# Altium schematic sheet jlin_transceiver_prototype.SchDoc
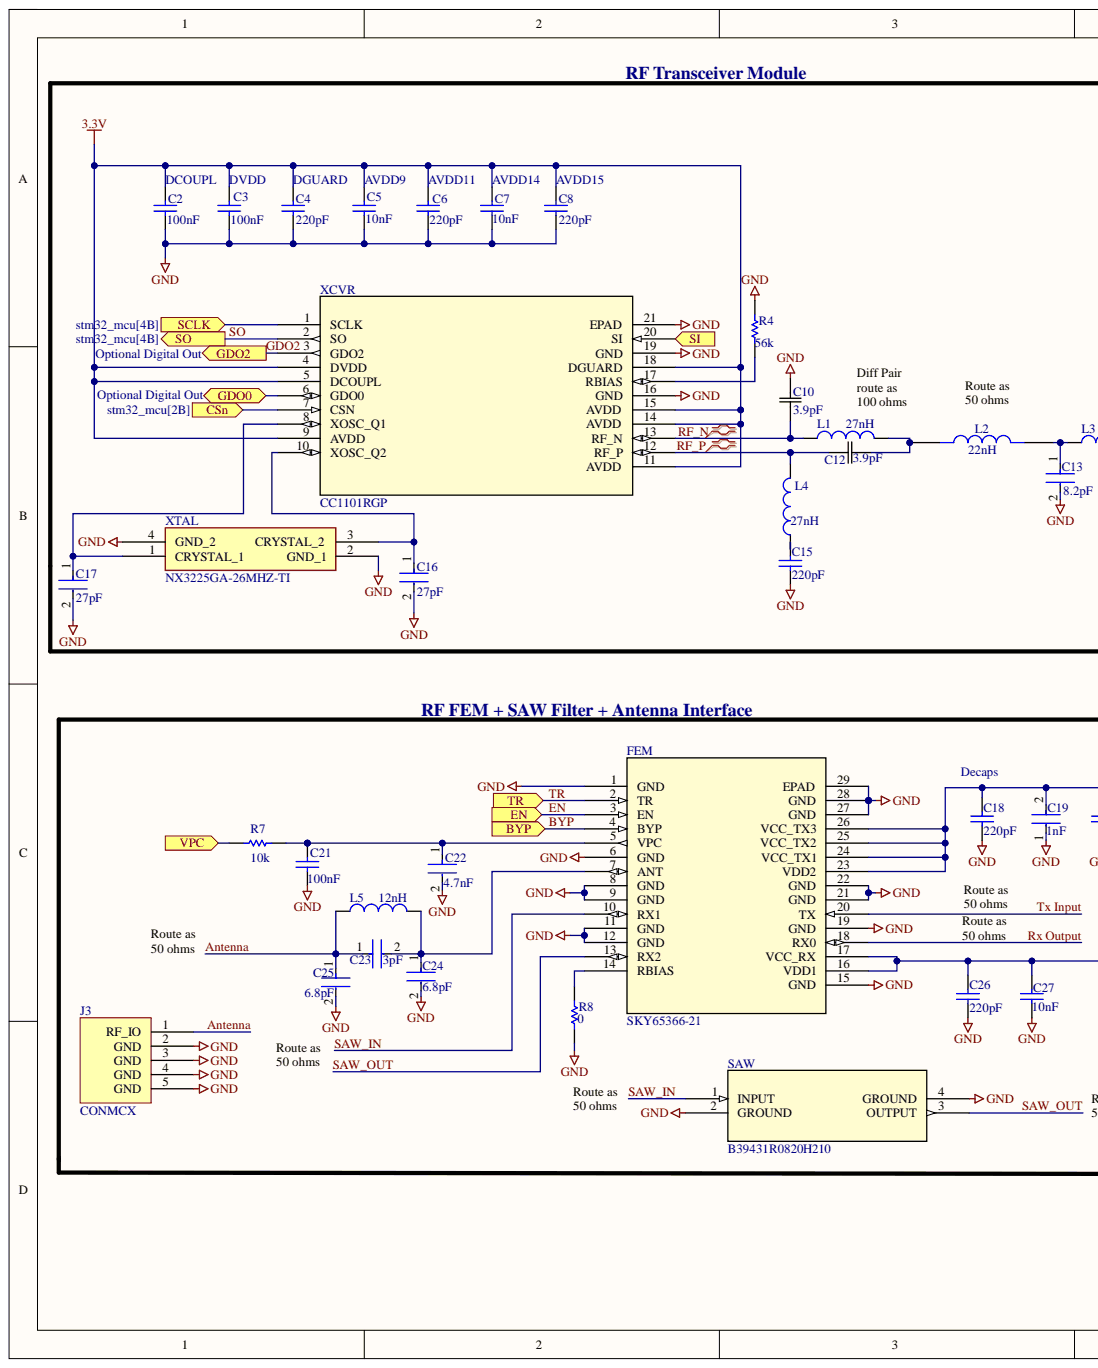
[diagram p0: jlin_transceiver_prototype.SchDoc, left]
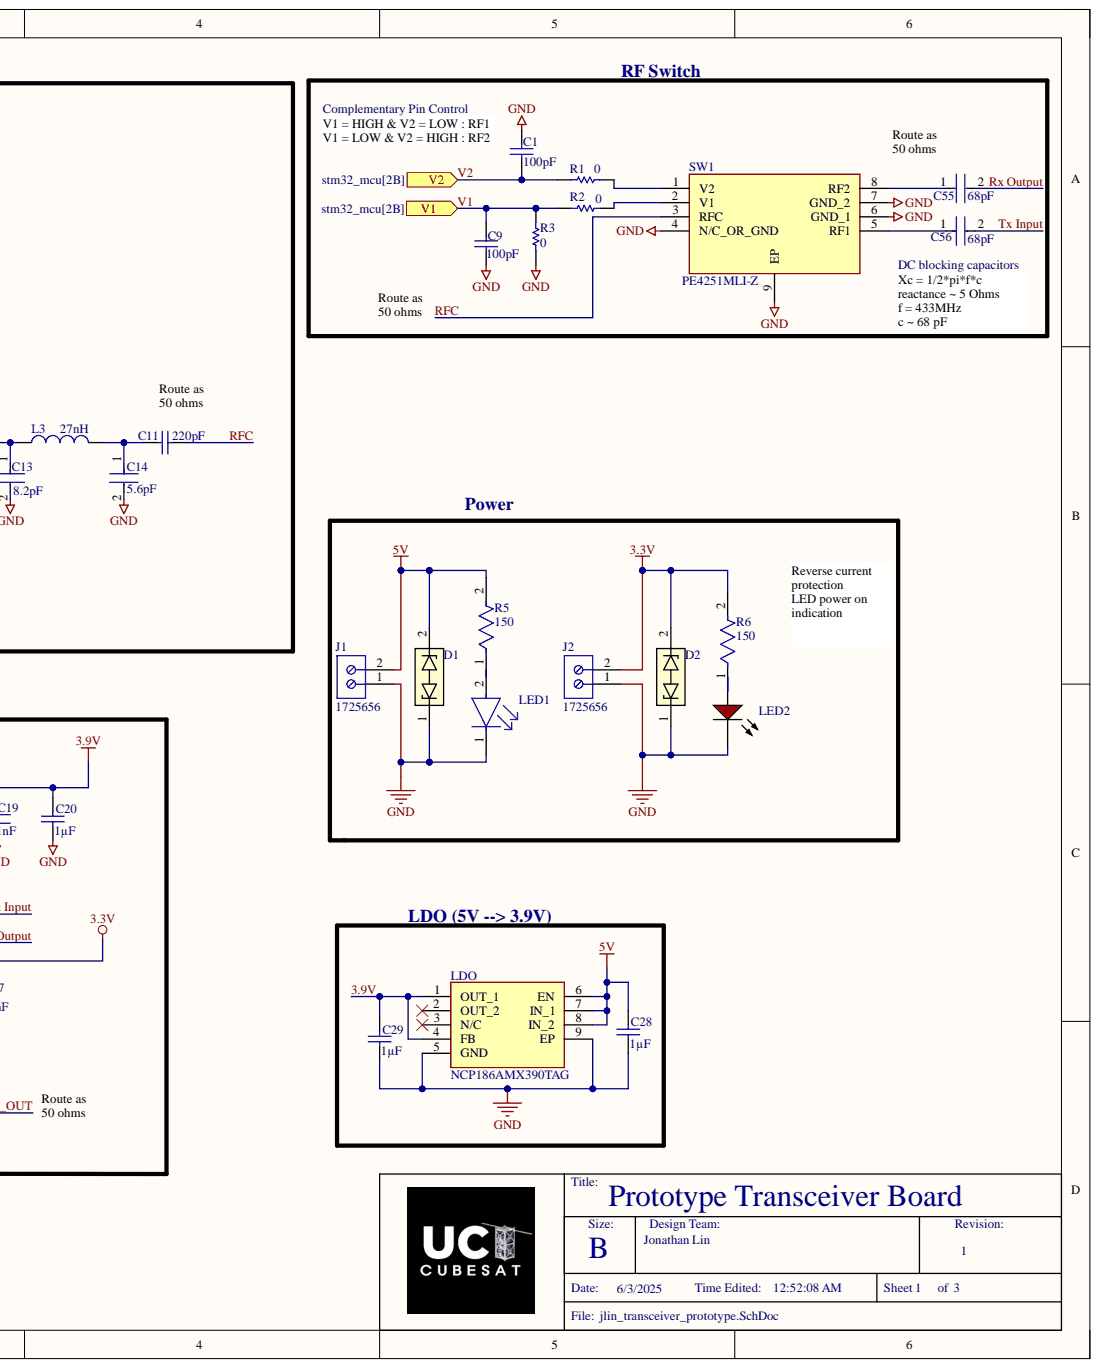
[diagram p1: jlin_transceiver_prototype.SchDoc, right]

## Parts
C1  value 100pF  [CAPC2013X70X45LL10T25]  (hidden comment: GRM2165C1H101JA01D)
C2  value 100nF  [CAPC2013X135X45ML10T25]  (hidden comment: GRM21BR72A104KAC4L)
C3  value 100nF  [CAPC2013X135X45ML10T25]  (hidden comment: GRM21BR72A104KAC4L)
C4  value 220pF  [CAPC2013X70X45LL10T25]  (hidden comment: GRM2165C1H221JA01D)
C5  value 10nF  [CAPC2013X135X45ML10T25]  (hidden comment: GRM21BR72A103KA01K)
C6  value 220pF  [CAPC2013X70X45LL10T25]  (hidden comment: GRM2165C1H221JA01D)
C7  value 10nF  [CAPC2013X135X45ML10T25]  (hidden comment: GRM21BR72A103KA01K)
C8  value 220pF  [CAPC2013X70X45LL10T25]  (hidden comment: GRM2165C1H221JA01D)
C9  value 100pF  [CAPC2013X70X45LL10T25]  (hidden comment: GRM2165C1H101JA01D)
C10  value 3.9pF  [CAP_CC0805_YAG]  (hidden comment: CC0805CRNPO9BN3R9)
C11  value 220pF  [CAPC2013X70X45LL10T25]  (hidden comment: GRM2165C1H221JA01D)
C12  value 3.9pF  [CAP_CC0805_YAG]  (hidden comment: CC0805CRNPO9BN3R9)
C13  value 8.2pF  [FP-0805-L_2_01_0_2-W_1_25-MFG]  (hidden comment: KGM21BCG2A8R2DT)
C14  value 5.6pF  [FP-C0805C-DN-IPC_A]  (hidden comment: C0805C569C5GACTU)
C15  value 220pF  [CAPC2013X70X45LL10T25]  (hidden comment: GRM2165C1H221JA01D)
C16  value 27pF  [FP-GRM21A-0_2-IPC_B]  (hidden comment: GRM21A5C2E270JW01D)
C17  value 27pF  [FP-GRM21A-0_2-IPC_B]  (hidden comment: GRM21A5C2E270JW01D)
C18  value 220pF  [CAPC2013X70X45LL10T25]  (hidden comment: GRM2165C1H221JA01D)
C19  value 1nF  [FP-GRM216-0_1-IPC_B]  (hidden comment: GRM2165C2A102JA01D)
C20  value 1µF  [CAPC2013X135X45LL10T25]  (hidden comment: GRM21BR71C105KA01L)
C21  value 100nF  [CAPC2013X135X45ML10T25]  (hidden comment: GRM21BR72A104KAC4L)
C22  value 4.7nF  [FP-GRM219-0_1-MFG]  (hidden comment: GRM2195C1H472JA01D)
C23  value 3pF  [FP-C0805C-DN-IPC_A]  (hidden comment: C0805C309C5GACTU)
C24  value 6.8pF  [FP-GQM219-0_15-MFG]  (hidden comment: GQM2195C2E6R8BB12D)
C25  value 6.8pF  [FP-GQM219-0_15-MFG]  (hidden comment: GQM2195C2E6R8BB12D)
C26  value 220pF  [CAPC2013X70X45LL10T25]  (hidden comment: GRM2165C1H221JA01D)
C27  value 10nF  [CAPC2013X135X45ML10T25]  (hidden comment: GRM21BR72A103KA01K)
C28  value 1µF  [CAPC2013X135X45LL10T25]  (hidden comment: GRM21BR71C105KA01L)
C29  value 1µF  [CAPC2013X135X45LL10T25]  (hidden comment: GRM21BR71C105KA01L)
C55  value 68pF  [FP-GRM21A-0_2-IPC_B]  (hidden comment: GRM21A5C2E680JW01D)
C56  value 68pF  [FP-GRM21A-0_2-IPC_B]  (hidden comment: GRM21A5C2E680JW01D)
D1  PESD3V3Z1BCSFYL  [SOD962-2_DSN0603-2_NEX]  (value not drawn)
D2  PESD3V3Z1BCSFYL  [SOD962-2_DSN0603-2_NEX]  (value not drawn)
FEM  SKY65366-21  [SKY65366-21-Footprint-1]
J1  1725656  [PHOE-1725656-2]
J2  1725656  [PHOE-1725656-2]
J3  CONMCX  [CONMCX002-Footprint-1]
L1  value 27nH  [WE-MK_0805_L2W1.25H0.85]  (hidden comment: 7447880127)
L2  value 22nH  [INDC2012X105N]  (hidden comment: AIMC-0805-22NJ-T)
L3  value 27nH  [WE-MK_0805_L2W1.25H0.85]  (hidden comment: 7447880127)
L4  value 27nH  [WE-MK_0805_L2W1.25H0.85]  (hidden comment: 7447880127)
L5  value 12nH  [AIMC-0805_ABR]  (hidden comment: AIMC-0805-12NJ-T)
LDO  NCP186AMX390TAG  [NCP186AMX330TAG]
LED1  LTST-C170KFKT  [FP-LTST-C170KFKT-MFG]  (value not drawn)
LED2  LTST-C190KRKT  [LTST-C191KRKT_V]  (value not drawn)
R1  value 0  [RESC2013X60X35ML10T20]  (hidden comment: Resistor 0R +/- 5% 0805 125 mW)
R2  value 0  [RESC2013X60X35ML10T20]  (hidden comment: Resistor 0R +/- 5% 0805 125 mW)
R3  value 0  [RESC2013X60X35ML10T20]  (hidden comment: Resistor 0R +/- 5% 0805 125 mW)
R4  value 56k  [RESC2013X70X40NL20T20]  (hidden comment: ERJ-6GEYJ563V)
R5  value 150  [FP-CRCW1210-e3-IPC_C]  (hidden comment: CRCW1210150RJNEA)
R6  value 150  [FP-CRCW1210-e3-IPC_C]  (hidden comment: CRCW1210150RJNEA)
R7  value 10k  [RESC2013X60X35LL10T20]  (hidden comment: RC0805FR-0710KL)
R8  value 0  [RESC2013X60X35ML10T20]  (hidden comment: Resistor 0R +/- 5% 0805 125 mW)
SAW  B39431R0820H210  [B39431R0820H210_QUA]
SW1  PE4251MLI-Z  [SOP65P490X106-9N]
XCVR  CC1101RGP  [CC1101RGP-Footprint-1]
XTAL  NX3225GA-26MHZ-TI  [NX3225GA_NDK]

## Ports
BYP, CSn, EN, GDO0, GDO2, SCLK, SI, SO, TR, V1, V2, VPC

## Nets
3.3V: C2.2 C3.2 C4.2 C5.2 C6.2 C7.2 C8.2 C26.2 C27.2 D2.2 FEM.16(VDD1) FEM.17(VCC_RX) J2.2 R6.2 XCVR.4(DVDD) XCVR.5(DCOUPL) XCVR.9(AVDD) XCVR.11(AVDD) XCVR.14(AVDD) XCVR.15(AVDD) XCVR.18(DGUARD)
5V: C28.2 D1.2 J1.2 LDO.6(EN) LDO.7(IN_1) LDO.8(IN_2) R5.2
GND: C1.2 C2.1 C3.1 C4.1 C5.1 C6.1 C7.1 C8.1 C9.1 C10.2 C13.2 C14.2 C15.1 C16.2 C17.2 C18.1 C19.1 C20.1 C21.1 C22.2 C24.2 C25.2 C26.1 C27.1 C28.1 C29.1 D1.1 D2.1 FEM.1(GND) FEM.6(GND) FEM.8(GND) FEM.9(GND) FEM.11(GND) FEM.12(GND) FEM.15(GND) FEM.19(GND) FEM.21(GND) FEM.22(GND) FEM.27(GND) FEM.28(GND) FEM.29(EPAD) J1.1 J2.1 J3.2(GND) J3.3(GND) J3.4(GND) J3.5(GND) LDO.5(GND) LDO.9(EP) LED1.1 LED2.2 R3.1 R4.2 R8.1 SAW.2(GROUND) SAW.4(GROUND) SW1.4(N/C_OR_GND) SW1.6(GND_1) SW1.7(GND_2) SW1.9(EP) XCVR.16(GND) XCVR.19(GND) XCVR.21(EPAD) XTAL.2(GND_1) XTAL.4(GND_2)
3.9V: C18.2 C19.2 C20.2 C29.2 FEM.23(VDD2) FEM.24(VCC_TX1) FEM.25(VCC_TX2) FEM.26(VCC_TX3) LDO.1(OUT_1) LDO.4(FB)
Antenna: C23.1 C25.1 J3.1(RF_IO) L5.1
BYP: FEM.4(BYP) ; port BYP
CSn: XCVR.7(CSN) ; port CSn
EN: FEM.3(EN) ; port EN
GDO0: XCVR.6(GDO0) ; port GDO0
GDO2: XCVR.3(GDO2) ; port GDO2
RF_N: C10.1 L1.1 XCVR.13(RF_N)
RF_P: C12.1 L4.2 XCVR.12(RF_P)
RFC: C11.2 SW1.3(RFC)
Rx Output: C55.2 FEM.18(RX0)
SAW_IN: FEM.10(RX1) SAW.1(INPUT)
SAW_OUT: FEM.13(RX2) SAW.3(OUTPUT)
SCLK: XCVR.1(SCLK) ; port SCLK
SI: XCVR.20(SI) ; port SI
SO: XCVR.2(SO) ; port SO
TR: FEM.2(TR) ; port TR
Tx Input: C56.2 FEM.20(TX)
V1: C9.2 R2.1 R3.2 ; port V1
V2: C1.1 R1.1 ; port V2
VPC: R7.1 ; port VPC
NetC11_1: C11.1 C14.1 L3.2
NetC12_2: C12.2 L1.2 L2.1
NetC13_1: C13.1 L2.2 L3.1
NetC15_2: C15.2 L4.1
NetC16_1: C16.1 XCVR.10(XOSC_Q2) XTAL.3(CRYSTAL_2)
NetC17_1: C17.1 XCVR.8(XOSC_Q1) XTAL.1(CRYSTAL_1)
NetC21_2: C21.2 C22.1 FEM.5(VPC) R7.2
NetC23_2: C23.2 C24.1 FEM.7(ANT) L5.2
NetC55_1: C55.1 SW1.8(RF2)
NetC56_1: C56.1 SW1.5(RF1)
NetFEM_14: FEM.14(RBIAS) R8.2
NetLED1_2: LED1.2 R5.1
NetLED2_1: LED2.1 R6.1
NetR1_2: R1.2 SW1.1(V2)
NetR2_2: R2.2 SW1.2(V1)
NetR4_1: R4.1 XCVR.17(RBIAS)

Not connected: LDO 2(OUT_2) 3(N/C)

## Library data of the parts
C1 C9 = CMP-001-00139-1: Ceramic Capacitor, Multilayer, Ceramic, 50V, 5% +Tol, 5% -Tol, C0G, -/+30ppm/Cel TC, 0.0001uF, Surface Mount, 0805; Multilayer: Yes; Capacitor Type: Ceramic; Case/Package: 0805; Component Kind: Standard; Dielectric Material: Ceramic; Height: 0.6mm; JESD-609 Code: e3; Length: 2mm; Max Operating Temperature: 125°C; Min Operating Temperature: -55°C; Mounting Technology: Surface Mount; Package Shape: Rectangular; Package Style: SMTMeter; Packaging: Tape and Reel; Pins: 2; Rated DC Voltage (URdc): 50V; Reach SVHC Compliant: Yes; RoHS Compliant: Yes; Temperature Characteristics Code: C0G; Terminal Finish: Nickel; Termination: Wraparound; Tolerance  (fill in as +-): 5%; Tolerance (fill in as +-): 5%; Width: 1.25mm; Library Name: University of California Irvine
C2 C3 C21 = CMP-001-00124-1: Ceramic Capacitor, Multilayer, Ceramic, 100V, 10% +Tol, 10% -Tol, X7R, 15% TC, 0.1uF, Surface Mount, 0805; Multilayer: Yes; Capacitor Type: Ceramic; Case/Package: 0805; Component Kind: Standard; Dielectric Material: Ceramic; Height: 1.25mm; JESD-609 Code: e3; Length: 2mm; Max Operating Temperature: 125°C; Min Operating Temperature: -55°C; Mounting Technology: Surface Mount; Package Shape: Rectangular; Package Style: SMTMeter; Packaging: Tape and Reel; Pins: 2; Rated DC Voltage (URdc): 100V; Reach SVHC Compliant: Yes; RoHS Compliant: Yes; Temperature Characteristics Code: X7R; Terminal Finish: Nickel; Termination: Wraparound; Tolerance  (fill in as +-): 10%; Tolerance (fill in as +-): 10%; Width: 1.25mm; Library Name: University of California Irvine
C4 C6 C8 C11 C15 C18 C26 = CMP-001-00130-1: Ceramic Capacitor, Multilayer, Ceramic, 50V, 5% +Tol, 5% -Tol, C0G, -/+30ppm/Cel TC, 0.00022uF, Surface Mount, 0805; Multilayer: Yes; Capacitor Type: Ceramic; Case/Package: 0805; Component Kind: Standard; Dielectric Material: Ceramic; Height: 0.6mm; JESD-609 Code: e3; Length: 2mm; Max Operating Temperature: 125°C; Min Operating Temperature: -55°C; Mounting Technology: Surface Mount; Package Shape: Rectangular; Package Style: SMTMeter; Packaging: Tape and Reel; Pins: 2; Rated DC Voltage (URdc): 50V; Reach SVHC Compliant: Yes; RoHS Compliant: Yes; Temperature Characteristics Code: C0G; Terminal Finish: Nickel; Termination: Wraparound; Tolerance  (fill in as +-): 5%; Tolerance (fill in as +-): 5%; Width: 1.25mm; Library Name: University of California Irvine
  C4 C6 C8: ComponentLink1Description: New link
C5 C7 C27 = CMP-001-00132-1: Ceramic Capacitor, Multilayer, Ceramic, 100V, 10% +Tol, 10% -Tol, X7R, 15% TC, 0.01uF, Surface Mount, 0805; Multilayer: Yes; Capacitor Type: Ceramic; Case/Package: 0805; Component Kind: Standard; Dielectric Material: Ceramic; Height: 1.25mm; JESD-609 Code: e3; Length: 2mm; Max Operating Temperature: 125°C; Min Operating Temperature: -55°C; Mounting Technology: Surface Mount; Package Shape: Rectangular; Package Style: SMTMeter; Packaging: Tape and Reel; Pins: 2; Rated DC Voltage (URdc): 100V; Reach SVHC Compliant: Yes; RoHS Compliant: Yes; Temperature Characteristics Code: X7R; Terminal Finish: Nickel; Termination: Wraparound; Tolerance  (fill in as +-): 10%; Tolerance (fill in as +-): 10%; Width: 1.25mm; Library Name: University of California Irvine
  C5 C7: ComponentLink1Description: New link
C10 C12 = CMP-001-00101-5: 3.9 pF ±0.25pF 50V Ceramic Capacitor C0G, NP0 0805 (2012 Metric); Mfr_Name: Yageo; Capacitor Type: Ceramic; Case/Package: 0805; Component Kind: Standard; Component Type: Standard; Design Item ID: CC0805CRNPO9BN3R9; Max Operating Temperature: 125°C; Min Operating Temperature: -55°C; Mounting Technology: Surface Mount; Pins: 2; RoHS Compliant: Yes; Source: CC0805CRNPO9BN3R9.SchLib; Library Name: University of California Irvine
C13 = CMP-001-00135-2: Cap Cer 8.2PF 100V C0G/NP0 0805; Capacitor Type: Ceramic; Multilayer: Yes; Temperature Characteristics Code: C0G; Tolerance  (fill in as +-): 6.0976%; Case/Package: 0805; Component Kind: Standard; Dielectric Material: Ceramic; Height: 0.94mm; JESD-609 Code: e3; Length: 2.01mm; Max Operating Temperature: 125°C; Min Operating Temperature: -55°C; Mounting Technology: Surface Mount; Package Shape: Rectangular; Package Style: SMTMeter; Packaging: Tape and Reel; Pins: 2; Rated DC Voltage (URdc): 100V; Reach SVHC Compliant: Yes; RoHS Compliant: Yes; Terminal Finish: Nickel; Termination: Wraparound; Tolerance (fill in as +-): 6.0976%; Width: 1.25mm; Library Name: University of California Irvine
C14 = CMP-001-00134-1: Ceramic Capacitor, Multilayer, Ceramic, 50V, 4.46% +Tol, 4.46% -Tol, C0G, 30ppm/Cel TC, 0.0000056uF, Surface Mount, 0805; Multilayer: Yes; Tolerance  (fill in as +-): 4.4643%; Capacitor Type: Ceramic; Case/Package: 0805; Component Kind: Standard; Dielectric Material: Ceramic; Height: 0.78mm; JESD-609 Code: e3; Length: 2mm; Max Operating Temperature: 125°C; Min Operating Temperature: -55°C; Mounting Technology: Surface Mount; Package Shape: Rectangular; Package Style: SMTMeter; Packaging: Tape and Reel; Pins: 2; Rated DC Voltage (URdc): 50V; Reach SVHC Compliant: Yes; RoHS Compliant: Yes; Temperature Characteristics Code: C0G; Terminal Finish: Nickel; Termination: Wraparound; Tolerance (fill in as +-): 4.4643%; Width: 1.25mm; Library Name: University of California Irvine
C16 C17 = CMP-001-00133-1: Ceramic Capacitor, Multilayer, Ceramic, 250V, 5% +Tol, 5% -Tol, C0G, 30ppm/Cel TC, 0.000027uF, Surface Mount, 0805; Multilayer: Yes; Capacitor Type: Ceramic; Case/Package: 0805; Component Kind: Standard; Dielectric Material: Ceramic; Height: 1mm; JESD-609 Code: e3; Length: 2mm; Max Operating Temperature: 125°C; Min Operating Temperature: -55°C; Mounting Technology: Surface Mount; Package Shape: Rectangular; Package Style: SMTMeter; Packaging: Tape and Reel; Pins: 2; Rated DC Voltage (URdc): 250V; Reach SVHC Compliant: Yes; RoHS Compliant: Yes; Temperature Characteristics Code: C0G; Terminal Finish: Nickel; Termination: Wraparound; Tolerance  (fill in as +-): 5%; Tolerance (fill in as +-): 5%; Width: 1.25mm; Library Name: University of California Irvine
C19 = CMP-001-00136-1: Ceramic Capacitor, Multilayer, Ceramic, 100V, 5% +Tol, 5% -Tol, C0G, 30ppm/Cel TC, 0.001uF, Surface Mount, 0805; Multilayer: Yes; Capacitor Type: Ceramic; Case/Package: 0805; Component Kind: Standard; Dielectric Material: Ceramic; Height: 0.6mm; JESD-609 Code: e3; Length: 2mm; Max Operating Temperature: 125°C; Min Operating Temperature: -55°C; Mounting Technology: Surface Mount; Package Shape: Rectangular; Package Style: SMTMeter; Packaging: Tape and Reel; Pins: 2; Rated DC Voltage (URdc): 100V; Reach SVHC Compliant: Yes; RoHS Compliant: Yes; Temperature Characteristics Code: C0G; Terminal Finish: Nickel; Termination: Wraparound; Tolerance  (fill in as +-): 5%; Tolerance (fill in as +-): 5%; Width: 1.25mm; Library Name: University of California Irvine
C20 C28 C29 = CMP-001-00129-1: Ceramic Capacitor, Multilayer, Ceramic, 16V, 10% +Tol, 10% -Tol, X7R, 15% TC, 1uF, Surface Mount, 0805; Multilayer: Yes; Capacitor Type: Ceramic; Case/Package: 0805; Component Kind: Standard; Dielectric Material: Ceramic; Height: 1.25mm; JESD-609 Code: e3; Length: 2mm; Max Operating Temperature: 125°C; Min Operating Temperature: -55°C; Mounting Technology: Surface Mount; Package Shape: Rectangular; Package Style: SMTMeter; Packaging: Tape and Reel; Pins: 2; Rated DC Voltage (URdc): 16V; Reach SVHC Compliant: Yes; RoHS Compliant: Yes; Temperature Characteristics Code: X7R; Terminal Finish: Nickel; Termination: Wraparound; Tolerance  (fill in as +-): 10%; Tolerance (fill in as +-): 10%; Width: 1.25mm; Library Name: University of California Irvine
C22 = CMP-001-00138-1: Ceramic Capacitor, Multilayer, Ceramic, 50V, 5% +Tol, 5% -Tol, C0G, 30ppm/Cel TC, 0.0047uF, Surface Mount, 0805; Multilayer: Yes; Capacitor Type: Ceramic; Case/Package: 0805; Component Kind: Standard; Dielectric Material: Ceramic; Height: 0.85mm; JESD-609 Code: e3; Length: 2mm; Max Operating Temperature: 125°C; Min Operating Temperature: -55°C; Mounting Technology: Surface Mount; Package Shape: Rectangular; Package Style: SMTMeter; Packaging: Tape and Reel; Pins: 2; Rated DC Voltage (URdc): 50V; Reach SVHC Compliant: Yes; RoHS Compliant: Yes; Temperature Characteristics Code: C0G; Terminal Finish: Nickel; Termination: Wraparound; Tolerance  (fill in as +-): 5%; Tolerance (fill in as +-): 5%; Width: 1.25mm; Library Name: University of California Irvine
C23 = CMP-001-00142-1: Ceramic Capacitor, Multilayer, Ceramic, 50V, 8.33% +Tol, 8.33% -Tol, C0G, 30ppm/Cel TC, 0.000003uF, Surface Mount, 0805; Multilayer: Yes; Tolerance  (fill in as +-): 8.3333%; Capacitor Type: Ceramic; Case/Package: 0805; Component Kind: Standard; Dielectric Material: Ceramic; Height: 0.78mm; JESD-609 Code: e3; Length: 2mm; Max Operating Temperature: 125°C; Min Operating Temperature: -55°C; Mounting Technology: Surface Mount; Package Shape: Rectangular; Package Style: SMTMeter; Packaging: Tape and Reel; Pins: 2; Rated DC Voltage (URdc): 50V; Reach SVHC Compliant: Yes; RoHS Compliant: Yes; Temperature Characteristics Code: C0G; Terminal Finish: Nickel; Termination: Wraparound; Tolerance (fill in as +-): 8.3333%; Width: 1.25mm; Library Name: University of California Irvine
C24 C25 = CMP-001-00143-1: Ceramic Capacitor, Multilayer, Ceramic, 250V, 1.47% +Tol, 1.47% -Tol, C0G, -/+30ppm/Cel TC, 0.0000068uF, Surface Mount, 0805; Multilayer: Yes; Capacitor Type: Ceramic; Case/Package: 0805; Component Kind: Standard; Dielectric Material: Ceramic; JESD-609 Code: e3; Max Operating Temperature: 125°C; Min Operating Temperature: -55°C; Mounting Technology: Surface Mount; Package Shape: Rectangular; Packaging: Tape and Reel; Pins: 2; Rated DC Voltage (URdc): 250V; Reach SVHC Compliant: Yes; RoHS Compliant: Yes; Temperature Characteristics Code: C0G; Terminal Finish: Nickel; Termination: Wraparound; Tolerance  (fill in as +-): 1.47%; Tolerance (fill in as +-): 1.47%; Library Name: University of California Irvine
C55 C56 = CMP-001-00140-1: Ceramic Capacitor, Multilayer, Ceramic, 250V, 5% +Tol, 5% -Tol, C0G, 30ppm/Cel TC, 0.000068uF, Surface Mount, 0805; Multilayer: Yes; Capacitor Type: Ceramic; Case/Package: 0805; Component Kind: Standard; Dielectric Material: Ceramic; Height: 1mm; JESD-609 Code: e3; Length: 2mm; Max Operating Temperature: 125°C; Min Operating Temperature: -55°C; Mounting Technology: Surface Mount; Package Shape: Rectangular; Package Style: SMTMeter; Packaging: Tape and Reel; Pins: 2; Rated DC Voltage (URdc): 250V; Reach SVHC Compliant: Yes; RoHS Compliant: Yes; Temperature Characteristics Code: C0G; Terminal Finish: Nickel; Termination: Wraparound; Tolerance  (fill in as +-): 5%; Tolerance (fill in as +-): 5%; Width: 1.25mm; Library Name: University of California Irvine
D1 D2 = CMP-004-00036-2: PESD3V3Zxx: 6.8 V 15 A 0.45 pF Bidirectional ESD Protection Diode - DSN0603-2; Component Kind: Standard; Library Name: University of California Irvine
FEM = CMP-00025-00009-1: RF and Baseband Circuit; Component Kind: Standard; RoHS Compliant: Yes; Library Name: Jonathan Lin
J1 J2 = CMP-2000-05299-1: Male Header, Pitch 2.54 mm, 1 x 2 Position, Height 9 mm, Tail Length 3.5 mm; Manufacturer: Phoenix Contact; Content: 250pc(s); Industrial packaging: Yes; Number of contacts: 2; Pitch: 2.54mm; System: Connector; Colour: Green; Component Kind: Standard; Datasheet URL: http://datasheet.octopart.com/1725656-Phoenix-Contact-datasheet-5302866.pdf; Datasheet Version: Apr-08; Library Name: Altium Content Vault; Manufacturer part No.: 1725656; Manufacturer URL: http://www.phoenixcontact.com/; Type: MPT0,5/2-2,54
J3 = CMP-00025-00005-4: MCX Connector Right Angle Jack (Female), PCB Through-Hole Mount, Gold; Component Kind: Standard; Library Name: University of California Irvine
L1 L3 L4 = CMP-006-00032-1: Multilayer Inductor, 27Nh, 0.3A, 0805 Rohs Compliant: Yes; Component Kind: Standard; Library Name: University of California Irvine
L2 = CMP-006-00036-1: IND 22nH 0.3A 500mâ„; MF: Abracon; Case/Package: 0805 Abracon; Check_prices: https://www.snapeda.com/parts/AIMC-0805-22NJ-T/Abracon/view-part/?ref=eda; Component Kind: Standard; Component Type: Standard; Design Item ID: AIMC-0805-22NJ-T; MP: AIMC-0805-22NJ-T; Pins: 2; SnapEDA_Link: https://www.snapeda.com/parts/AIMC-0805-22NJ-T/Abracon/view-part/?ref=snap; Source: AIMC-0805-22NJ-T.SchLib; Library Name: University of California Irvine
L5 = CMP-006-00034-1: General Purpose Inductor, 0.012uH, 5%, 1 Element, Ceramic-Core, SMD, 0805; Case/Size Code: 0805; Channels: 1; DC Resistance (DCR): 350mR; Inductor Application: RF INDUCTOR; Max Current Rating: 300mA; Quality Factor-Min (at L-nom): 100; Self Resonant Frequency: 1.9GHz; Shape/Size Description: RECTANGULAR PACKAGE; Shielding: Unshielded; Test Frequency: 15MHz; Component Kind: Standard; Core Material: Ceramic; Inductance-Nom (L): 0.012uH; Inductor Type: GENERAL PURPOSE INDUCTOR; Manufacturer Series: AIMC-0805; Max Operating Temperature: 125°C; Min Operating Temperature: -55°C; Pins: 2; Reach SVHC Compliant: Yes; RoHS Compliant: Yes; Surface Mount: Yes; Terminal Placement: Dual Ended; Termination: Wraparound; Tolerance: 5%; Library Name: University of California Irvine
LDO = CMP-031-00016-1: 1.8 V to 5.5 V Operating Input Voltage Range; Manufacturer_Name: onsemi; Arrow Part Number: NCP186AMX390TAG; Arrow Price/Stock: https://www.arrow.com/en/products/ncp186amx390tag/on-semiconductor?region=nac; Component Kind: Standard; Datasheet Link: https://www.onsemi.com/pub/Collateral/NCP186-D.PDF; Design Item ID: NCP186AMX390TAG; Manufacturer_Part_Number: NCP186AMX390TAG; Mouser Part Number: 863-NCP186AMX390TAG; Mouser Price/Stock: https://www.mouser.co.uk/ProductDetail/onsemi/NCP186AMX390TAG?qs=tCMd4XlZ%2FiBm0g5PCqviEQ%3D%3D; Source: SamacSys.SchLib; Library Name: University of California Irvine
LED1 = CMP-007-00038-1: Single Color LED, Orange, Water Clear, 1.4mm; Channels: 1; Forward Current: 30mA; Forward Voltage: 2.4V; Luminous Intensity-Nom: 60mcd; Overall Height: 1.1mm; Reverse Voltage-Max: 5V; Shape: Rectangular; Viewing Angle: 130°; Color: Orange; Color@wavelength: Orange; Component Kind: Standard; JESD-609 Code: e3; Lens Style: WATER CLEAR; Max Operating Temperature: 85°C; Min Operating Temperature: -55°C; Mounting Technology: Surface Mount; Optoelectronic Device Type: SINGLE COLOR LED; Packaging: Tape and Reel; Pins: 2; Reach SVHC Compliant: Yes; RoHS Compliant: Yes; Size: 1.4mm; Terminal Finish: Tin; Terminal Pitch: 2.1mm; Library Name: University of California Irvine
LED2 = CMP-007-00024-2: LED Uni-Color Red, 62.5 mW, 25 mA, -30 to 85 degC, 2-Pin SMD, RoHS, Tape and Reel; Manufacturer: Lite-On; Forward Current: 20mA; Forward Voltage: 2V; Lens Style: Diffused; Luminous Intensity: 54mcd; Power: 75mW; Viewing Angle: 130degrees; Case/Package: 0603; Colour: Red; Component Kind: Standard; Datasheet URL: http://optoelectronics.liteon.com/upload/download/DS-22-99-0151/S_110_LTST-C190KRKT.pdf; Datasheet Version: Rev. F, 05/2013; Illumination Colour: Red; Manufacturer URL: http://www.us.liteon.com/; Max Operating Temperature: 85°C; Min Operating Temperature: -55°C; Mounting Technology: SM; Nominal Vf: 2V; Package Description: 2-Pin Surface Mount Device, Body 1.6 x 0.8 mm; Package Quantity: 3000; Package Reference: C191KRKT; Packaging: TapeandReel; Pins: 2; RoHS Compliant: True; Library Name: University of California Irvine
R1-R3 R8 = CMP-009-00007-5: Chip Resistor, 0 Ohm, 0.125 W, -55 to 155 degC, 0805 (2012 Metric); Power: 125mW; Voltage Rating: 50V; Case/Package: 0805; Component Kind: Standard; Max Operating Temperature: 155°C; Min Operating Temperature: -55°C; Mounting Technology: SM; Pins: 2; RoHS Compliant: True; Tolerance: 5%; Library Name: University of California Irvine
R4 = CMP-009-00202-1: Fixed Resistor, Metal Glaze/thick Film, 0.125W, 56000ohm, 150V, 5% +/-Tol, 200ppm/Cel, Surface Mount, 0805; Package Height: 0.6mm; Package Length: 2mm; Package Width: 1.25mm; Power: 125mW; Rated Temperature: 70°C; Reference Standard: AEC-Q200; Temperature Coefficient (ppm/Cel): 200; Case/Package: 0805; Component Kind: Standard; Construction: Rectangular; JESD-609 Code: e3; Max Operating Temperature: 155°C; Min Operating Temperature: -55°C; Mounting Technology: Surface Mount; Package Shape: Rectangular; Packaging: Tape and Reel; Pins: 2; Reach SVHC Compliant: Yes; Resistor Type: FIXED RESISTOR; RoHS Compliant: Yes; Technology: METAL GLAZE/THICK FILM; Terminal Finish: Nickel; Termination: Wraparound; Tolerance: 5%; Working Voltage: 150V; Library Name: University of California Irvine
R5 R6 = CMP-009-00203-1: Fixed Resistor, Metal Glaze/thick Film, 0.5W, 150ohm, 200V, 5% +/-Tol, 200ppm/Cel, Surface Mount, 1210; Additional Feature: RATED AC VOLTAGE (V): 200; Package Height: 0.55mm; Package Length: 3.2mm; Package Width: 2.5mm; Power: 500mW; Rated Temperature: 70°C; Reference Standard: AEC-Q200; Temperature Coefficient (ppm/Cel): 200; Case/Package: 1210; Component Kind: Standard; Construction: Chip; JESD-609 Code: e3; Max Operating Temperature: 155°C; Min Operating Temperature: -55°C; Mounting Technology: Surface Mount; Package Shape: Rectangular; Packaging: Tape and Reel; Pins: 2; Reach SVHC Compliant: Yes; Resistor Type: FIXED RESISTOR; RoHS Compliant: Yes; Technology: METAL GLAZE/THICK FILM; Terminal Finish: Nickel; Termination: Wraparound; Tolerance: 5%; Working Voltage: 200V; Library Name: University of California Irvine
R7 = CMP-009-00200-1: Fixed Resistor, Metal Glaze/thick Film, 0.125W, 10000ohm, 150V, 1% +/-Tol, 100ppm/Cel, Surface Mount, 0805; Additional Feature: LASER TRIMMABLE; Package Height: 0.5mm; Package Length: 2mm; Package Width: 1.25mm; Power: 125mW; Rated Temperature: 70°C; Temperature Coefficient (ppm/Cel): 100; Case/Package: 0805; Component Kind: Standard; Construction: Chip; JESD-609 Code: e3; Max Operating Temperature: 155°C; Min Operating Temperature: -55°C; Mounting Technology: Surface Mount; Package Shape: Rectangular; Packaging: Tape and Reel; Pins: 2; Reach SVHC Compliant: Yes; Resistor Type: FIXED RESISTOR; RoHS Compliant: Yes; Technology: METAL GLAZE/THICK FILM; Terminal Finish: Nickel; Termination: Wraparound; Tolerance: 1%; Working Voltage: 150V; Library Name: University of California Irvine
SAW = CMP-00025-00006-1: 433.92MHz SAW Resonator50 Ohm -40°C ~ 125°C Surface Mount; Component Kind: Standard; Library Name: University of California Irvine
SW1 = CMP-031-00015-1: IC RF SWITCH SPDT 8-MSOP; Manufacturer_Name: Peregrine Semiconductor; Component Kind: Standard; ComponentLink1Description: New link; Datasheet Link: http://www.psemi.com/pdf/datasheets/pe4251ds.pdf; Design Item ID: PE4251MLI-Z; Height: 1.06mm; Manufacturer_Part_Number: PE4251MLI-Z; Mouser Part Number: 81-PE4251MLI-Z; Mouser Price/Stock: https://www.mouser.co.uk/ProductDetail/pSemi/PE4251MLI-Z?qs=iw0hurA%2FaD2z5EU4g7%252BX%2Fg%3D%3D; Source: SamacSys.SchLib; Library Name: University of California Irvine
XCVR = CMP-00025-00007-1: RF and Baseband Circuit, PQCC20; Case/Package: HVQCCN; Component Kind: Standard; Max Operating Temperature: 85°C; Min Operating Temperature: -40°C; Pins: 20; RoHS Compliant: Yes; Library Name: Jonathan Lin
XTAL = CMP-003-00033-2: Crystal 26.0000MHZ 10PF SMD; Component Kind: Standard; Library Name: University of California Irvine
